# Altium schematic sheet Power_System.SchDoc
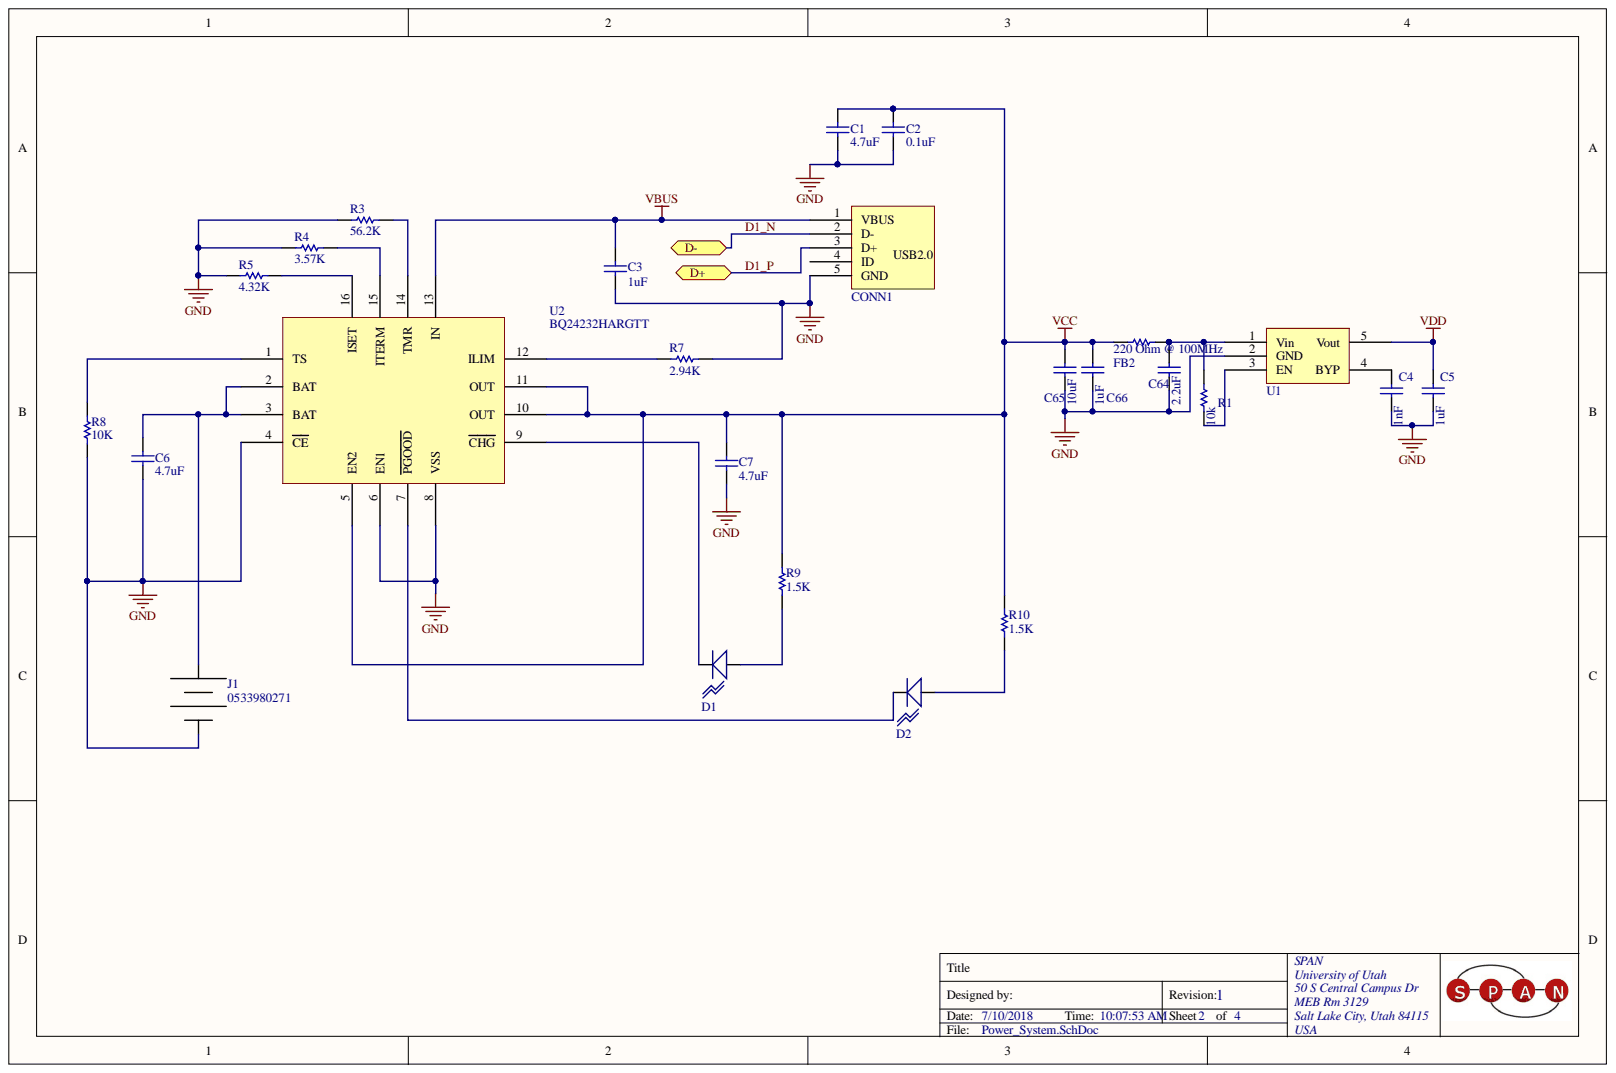
[diagram p0: Power_System.SchDoc]

## Parts
C1  4.7uF  [0603_Cap]
C2  0.1uF  [0603_Cap]
C3  1uF  [0603_Cap]
C4  10uF  [0603_Cap]  (value not drawn)
C5  GRM188R61A105KA61D  [CAPC1608X08N (0603)]  (value not drawn)
C6  4.7uF  [0603_Cap]
C7  4.7uF  [0603_Cap]
C64  GRM188F51A225ZE01D  [CAPC1608X08N (0603)]  (value not drawn)
C65  GRM188R60J106ME47D  [CAPC1608X08N (0603)]  (value not drawn)
C66  GRM188R61A105KA61D  [CAPC1608X08N (0603)]  (value not drawn)
CONN1  10118193-0001LF  [USB_micro_B]  (value not drawn)
D1  LTST-C191KFKT  [0603_LED]  (value not drawn)
D2  LTST-C191KFKT  [0603_LED]  (value not drawn)
FB2  Impedance @ Frequency: 220 Ohm @ 100MHz  [RESC1608X06N (0603)]  (hidden comment: BLM18BD221SN1D)
J1  0533980271  [53398-0271]
R1  10K  [0603_RES]
R3  56.2K  [0603_RES]
R4  3.57K  [0603_RES]
R5  4.32K  [0603_RES]
R7  2.94K  [0603_RES]
R8  10K  [0603_RES]
R9  1.5K  [0603_RES]
R10  1.5K  [0603_RES]
U1  TPS2147IDGQG4  [SOT95P280X145-5N]  (value not drawn)
U2  BQ24232HARGTT  [QFN_16_BQ24232]

## Ports
D+, D-

## Nets
GND: C1.1 C2.1 C3.1 C4.1 C5.1 C6.1 C7.1 C64.1 C65.1 C66.1 CONN1.5(GND) J1.2 R3.1 R4.1 R5.1 R7.2 R8.1 U1.2(GND) U2.4(CE) U2.6(EN1) U2.8(VSS)
VBUS: C3.2 CONN1.1(VBUS) U2.13(IN)
VCC: C1.2 C2.2 C7.2 C65.2 C66.2 FB2.1 R9.2 R10.2 U2.5(EN2) U2.10(OUT) U2.11(OUT)
VDD: C5.2 U1.5(Vout)
D1_N: CONN1.2(D-)
D1_P: CONN1.3(D+)
NetC4_2: C4.2 U1.4(BYP)
NetC6_2: C6.2 J1.1 U2.2(BAT) U2.3(BAT)
NetC64_2: C64.2 FB2.2 R1.2 U1.1(Vin)
NetD1_1: D1.1 R9.1
NetD1_2: D1.2 U2.9(CHG)
NetD2_1: D2.1 R10.1
NetD2_2: D2.2 U2.7(PGOOD)
NetR1_1: R1.1 U1.3(EN)
NetR3_2: R3.2 U2.14(TMR)
NetR4_2: R4.2 U2.15(ITERM)
NetR5_2: R5.2 U2.16(ISET)
NetR7_1: R7.1 U2.12(ILIM)
NetR8_2: R8.2 U2.1(TS)

Not connected: CONN1 4(ID)

## Library data of the parts
C1 C6 C7 = JMK107BJ475MAHT: Manufacturer: Taiyo Yuden; Capacitance: 4.7µF; Temperature Coefficient: X5R; Tolerance: ±20%; Voltage - Rated: 6.3V; Applications: Automotive, SMPS Filtering; Category: Capacitors; Component Kind: Standard; ComponentLink1Description: http://www.yuden.co.jp/productdata/catalog/en/mlcc_all_hq_e.pdf; ComponentLink1URL: http://www.yuden.co.jp/productdata/catalog/en/mlcc_all_hq_e.pdf; Description: 4.7uF; Failure Rate: -; Features: -; Height - Seated (Max): -; Lead Spacing: -; Lead Style: -; Manufacturer Part Number: JMK107BJ475MAHT; Mounting Type: Surface Mount, MLCC; Operating Temperature: -55°C ~ 85°C; Package / Case: 0603 (1608 Metric); Packaging: Tape & Reel (TR); Part Status: Active; Pricing 1: 4000=0.03053, 8000=0.0284, 12000=0.02698, 28000=0.02556, 100000=0.01935 (USD); Ratings: AEC-Q200; RoHS: RoHS Compliant; Size / Dimension: 0.063" L x 0.031" W (1.60mm x 0.80mm); Stock 1: 4000; Supplier 1: Digi-Key; Supplier Part Number 1: 587-4903-2-ND; Thickness (Max): 0.035" (0.90mm); Library Name: Sitara.SchLib
C2 = 0603YC104KAT4A: CAP CER 0.1UF 16V X7R 0603; Manufacturer: AVX Corporation; Capacitance: 0.1µF; Tolerance: ±10%; Applications: General Purpose; Category: Capacitors; Component Kind: Standard; ComponentLink1Description: http://datasheets.avx.com/X7RDielectric.pdf; ComponentLink1URL: http://datasheets.avx.com/X7RDielectric.pdf; Failure Rate: -; Features: -; Height - Seated (Max): -; Lead Spacing: -; Lead Style: -; Manufacturer Part Number: 0603YC104KAT4A; Mounting Type: Surface Mount, MLCC; Operating Temperature: -55°C ~ 125°C; Package / Case: 0603 (1608 Metric); Packaging: Tape & Reel (TR); Part Status: Active; Pricing 1: 15000=0.00344, 30000=0.00327, 75000=0.00292, 105000=0.00237 (USD); Ratings: -; RoHS: RoHS Compliant; Size / Dimension: 0.063" L x 0.032" W (1.60mm x 0.81mm); Stock 1: 15000; Supplier 1: Digi-Key; Supplier Part Number 1: 478-10054-2-ND; Temperature Coefficient: X7R; Thickness (Max): 0.035" (0.90mm); Voltage - Rated: 16V; Library Name: Sitara.SchLib
C3 = JMK107C6105KA-T: CAP CER 1uF SMD; Manufacturer: Taiyo Yuden; Capacitance: 1µF; Temperature Coefficient: X6S; Tolerance: ±10%; Voltage - Rated: 6.3V; Applications: SMPS Filtering; Category: Capacitors; Component Kind: Standard; ComponentLink1Description: http://www.yuden.co.jp/productdata/catalog/en/mlcc_all_e.pdf; ComponentLink1URL: http://www.yuden.co.jp/productdata/catalog/en/mlcc_all_e.pdf; Failure Rate: -; Features: -; Height - Seated (Max): -; Lead Spacing: -; Lead Style: -; Manufacturer Part Number: JMK107C6105KA-T; Mounting Type: Surface Mount, MLCC; Operating Temperature: -55°C ~ 105°C; Package / Case: 0603 (1608 Metric); Packaging: Tape & Reel (TR); Part Status: Active; Pricing 1: 4000=0.02281, 8000=0.02122, 12000=0.02016, 28000=0.0191, 100000=0.01446 (USD); Ratings: -; RoHS: RoHS Compliant; Size / Dimension: 0.063" L x 0.031" W (1.60mm x 0.80mm); Stock 1: 8000; Supplier 1: Digi-Key; Supplier Part Number 1: 587-4904-2-ND; Thickness (Max): 0.035" (0.90mm); Library Name: Sitara.SchLib
C4 = GRM1885C1H102JA01D: CAP CER 1000PF 50V 5% NP0 0603; Manufacturer: Murata Electronics North America; Temperature Coefficient: C0G, NP0; Applications: General Purpose; Capacitance: 1000pF; Category: Capacitors; Component Kind: Standard; ComponentLink1Description: http://www.murata.com/products/catalog/pdf/c02e.pdf; ComponentLink1URL: http://www.murata.com/products/catalog/pdf/c02e.pdf; Features: -; Height - Seated (Max): -; Lead Spacing: -; Lead Style: -; Manufacturer Part Number: GRM1885C1H102JA01D; Mounting Type: Surface Mount, MLCC; Operating Temperature: -55°C ~ 125°C; Package / Case: 0603 (1608 Metric); Packaging: Tape & Reel (TR); Pricing 1: 4000=0.00863, 8000=0.00788, 12000=0.0075, 28000=0.00713, 100000=0.00516 (USD); Ratings: -; RoHS: RoHS Compliant; Size / Dimension: 0.063" L x 0.031" W (1.60mm x 0.80mm); Stock 1: 140000; Supplier 1: Digi-Key; Thickness (Max): 0.035" (0.90mm); Tolerance: ±5%; Voltage - Rated: 50V; Library Name: Sara.SchLib
C5 C66 = GRM188R61A105KA61D: CAP CER 1UF 10V 10% X5R 0603; Manufacturer: Murata Electronics North America; Capacitance: 1.0µF; Applications: General Purpose; Category: Capacitors; Component Kind: Standard; ComponentLink1Description: http://search.murata.co.jp/Ceramy/image/img/A01X/G101/ENG/GRM188R61A105KA61-01.pdf; ComponentLink1URL: http://search.murata.co.jp/Ceramy/image/img/A01X/G101/ENG/GRM188R61A105KA61-01.pdf; Features: -; Height - Seated (Max): -; Lead Spacing: -; Lead Style: -; Manufacturer Part Number: GRM188R61A105KA61D; Mounting Type: Surface Mount, MLCC; Operating Temperature: -55°C ~ 85°C; Package / Case: 0603 (1608 Metric); Packaging: Cut Tape (CT); Pricing 1: 1=0.1, 10=0.041, 50=0.0224, 100=0.019, 250=0.01552, 500=0.01328, 1000=0.01035 (USD); Ratings: -; RoHS: RoHS Compliant; Size / Dimension: 0.063" L x 0.031" W (1.60mm x 0.80mm); Stock 1: 244919; Supplier 1: Digi-Key; Temperature Coefficient: X5R; Thickness (Max): 0.035" (0.90mm); Tolerance: ±10%; Voltage - Rated: 10V; Library Name: Sara.SchLib
C64 = GRM188F51A225ZE01D: CAP CER 2.2UF 10V Y5V 0603; Manufacturer: Murata Electronics North America; Capacitance: 2.2µF; Temperature Coefficient: Y5V (F); Tolerance: -20%, +80%; Applications: General Purpose; Category: Capacitors; Component Kind: Standard; ComponentLink1Description: http://search.murata.co.jp/Ceramy/image/img/A01X/G101/ENG/GRM188F51A225ZE01-01.pdf; ComponentLink1URL: http://search.murata.co.jp/Ceramy/image/img/A01X/G101/ENG/GRM188F51A225ZE01-01.pdf; Features: -; Height - Seated (Max): -; Lead Spacing: -; Lead Style: -; Manufacturer Part Number: GRM188F51A225ZE01D; Mounting Type: Surface Mount, MLCC; Operating Temperature: -30°C ~ 85°C; Package / Case: 0603 (1608 Metric); Packaging: Tape & Reel (TR); Pricing 1: 4000=0.02043, 8000=0.019, 12000=0.01805, 28000=0.0171 (USD); Ratings: -; RoHS: RoHS Compliant; Size / Dimension: 0.063" L x 0.031" W (1.60mm x 0.80mm); Stock 1: 8000; Supplier 1: Digi-Key; Thickness (Max): 0.035" (0.90mm); Voltage - Rated: 10V; Library Name: Sara.SchLib
C65 = GRM188R60J106ME47D: CAP CER 10UF 6.3V 20% X5R 0603; Manufacturer: Murata Electronics North America; Capacitance: 10µF; Applications: General Purpose; Category: Capacitors; Component Kind: Standard; ComponentLink1Description: http://search.murata.co.jp/Ceramy/image/img/A01X/G101/ENG/GRM188R60J106ME47-01.pdf; ComponentLink1URL: http://search.murata.co.jp/Ceramy/image/img/A01X/G101/ENG/GRM188R60J106ME47-01.pdf; Features: -; Height - Seated (Max): -; Lead Spacing: -; Lead Style: -; Manufacturer Part Number: GRM188R60J106ME47D; Mounting Type: Surface Mount, MLCC; Operating Temperature: -55°C ~ 85°C; Package / Case: 0603 (1608 Metric); Packaging: Digi-Reel®; Pricing 1: 1=0.24, 10=0.2, 50=0.1126, 100=0.1, 250=0.075, 500=0.06626, 1000=0.05875 (USD); Ratings: -; RoHS: RoHS Compliant; Size / Dimension: 0.063" L x 0.031" W (1.60mm x 0.80mm); Stock 1: 2128324; Supplier 1: Digi-Key; Supplier 2: Digi-Key; Temperature Coefficient: X5R; Thickness (Max): 0.035" (0.90mm); Tolerance: ±20%; Voltage - Rated: 6.3V; Library Name: Sara.SchLib
CONN1 = 10118193-0001LF: CONN USB MICRO B RECPT SMT R/A; Manufacturer: Amphenol FCI; Connector Type: USB - micro B; Current Rating: 1.8A per Contact; Gender: Receptacle; Material Flammability Rating: UL94 V-0; Mating Cycles: 10000; Number of Contacts: 5; Number of Ports: 1; Shielding: Shielded; Specifications: USB 2.0; Voltage - Rated: 100VAC; Category: Connectors, Interconnects; Component Kind: Standard; ComponentLink1Description: http://portal.fciconnect.com/Comergent//fci/drawing/10118193.pdf; ComponentLink1URL: http://portal.fciconnect.com/Comergent//fci/drawing/10118193.pdf; Contact Finish: Gold; Features: Solder Retention; Ingress Protection: -; Insulation Color: Black; Manufacturer Part Number: 10118193-0001LF; Mounting Feature: Horizontal; Mounting Type: Surface Mount, Right Angle; Through Hole; Operating Temperature: -30°C ~ 80°C; Packaging: Tape & Reel (TR); Part Status: Active; Pricing 1: 1200=0.19845, 2400=0.18144, 6000=0.1701, 8400=0.15876, 12000=0.15309, 30000=0.15082, 60000=0.14742 (USD); RoHS: RoHS Compliant; Shell/Shielding Material: Steel, Stainless; Stock 1: 88800; Supplier 1: Digi-Key; Supplier Part Number 1: 609-4616-2-ND; Termination: Solder; Library Name: Sitara.SchLib
D1 D2 = LTST-C191KFKT: LED ORANGE CLEAR 0603 SMD; Manufacturer: Lite-On Inc.; Current - Test: 20mA; Lens Color: Colorless; Lens Style/Size: Rectangle with Flat Top, 1.10mm x 0.80mm; Millicandela Rating: 90mcd; Viewing Angle: 130°; Voltage - Forward (Vf) (Typ): 2V; Wavelength - Dominant: 605nm; Wavelength - Peak: 611nm; Category: Optoelectronics; Color: Orange; Component Kind: Standard; ComponentLink1Description: http://optoelectronics.liteon.com/upload/download/DS22-2000-222/S_110_LTST-C191KFKT.pdf; ComponentLink1URL: http://optoelectronics.liteon.com/upload/download/DS22-2000-222/S_110_LTST-C191KFKT.pdf; Features: -; Height (Max): 0.55mm; Lens Transparency: Clear; Manufacturer Part Number: LTST-C191KFKT; Mounting Type: Surface Mount; Package / Case: 0603 (1608 Metric); Packaging: Tape & Reel (TR); Part Status: Active; Pricing 1: 5000=0.0376, 10000=0.03384, 25000=0.03008, 50000=0.02726, 125000=0.02538 (USD); RoHS: RoHS Compliant; Size / Dimension: 1.60mm L x 0.80mm W; Stock 1: 160000; Supplier 1: Digi-Key; Supplier Part Number 1: 160-1445-2-ND; Library Name: Sitara.SchLib
FB2 = BLM18BD221SN1D: FERRITE CHIP 220 OHM 200MA 0603; Manufacturer: Murata Electronics North America; DC Resistance (DCR): 450 mOhm Max; Filter Type: Differential Mode - Single; Category: Filters; Component Kind: Standard; ComponentLink1Description: http://search.murata.co.jp/Ceramy/image/img/PDF/ENG/L0110S0100BLM18B.pdf; ComponentLink1URL: http://search.murata.co.jp/Ceramy/image/img/PDF/ENG/L0110S0100BLM18B.pdf; Current Rating: 200mA; Height (Max): 0.037" (0.95mm); Manufacturer Part Number: BLM18BD221SN1D; Mounting Type: Surface Mount; Package / Case: 0603 (1608 Metric); Packaging: Cut Tape (CT); Pricing 1: 1=0.1, 10=0.087, 50=0.0712, 100=0.0523, 250=0.038, 500=0.03484, 1000=0.0301 (USD); RoHS: RoHS Compliant; Size / Dimension: 0.063" L x 0.031" W (1.60mm x 0.80mm); Stock 1: 35645; Supplier 1: Digi-Key; Library Name: Sara.SchLib
J1 = 0533980271: CONN HEADER 2POS 1.25MM VERT SMD; Manufacturer: Molex Inc; Connector Type: Header, Male Blades; Number of Positions: 2; Pitch: 0.049" (1.25mm); Category: Connectors, Interconnects; Color: Natural; Component Kind: Standard; ComponentLink1Description: http://www.molex.com/pdm_docs/sd/533980271_sd.pdf; ComponentLink1URL: http://www.molex.com/pdm_docs/sd/533980271_sd.pdf; Connector Style: -; Features: -; Manufacturer Part Number: 0533980271; Mounting Type: Surface Mount; Packaging: Cut Tape (CT); Pricing 1: 1=1.4, 10=1.091, 25=1.0168, 50=0.923, 100=0.8323, 250=0.7014, 500=0.66448 (USD); RoHS: RoHS Compliant; Stock 1: 14126; Supplier 1: Digi-Key; Supplier Part Number 1: WM7606CT-ND; Termination: Solder; Wire Gauge: -; Library Name: Chronos.SchLib
R1 = RC0603FR-0710KL: RES 10.0K OHM 1/10W 1% 0603 SMD; Manufacturer: Yageo; Power (Watts): 0.1W, 1/10W; Temperature Coefficient: ±100ppm/°C; Category: Resistors; Component Kind: Standard; ComponentLink1Description: http://www.yageo.com/documents/recent/PYu-RC0603_51_RoHS_L_4.pdf; ComponentLink1URL: http://www.yageo.com/documents/recent/PYu-RC0603_51_RoHS_L_4.pdf; Composition: Thick Film; Features: -; Height: 0.022" (0.55mm); Manufacturer Part Number: RC0603FR-0710KL; Number of Terminations: 2; Package / Case: 0603 (1608 Metric); Packaging: Tape & Reel (TR); Pricing 1: 5000=0.00171, 10000=0.00149, 25000=0.00131, 50000=0.0012, 125000=0.00117 (USD); Resistance (Ohms): 10k; RoHS: RoHS Compliant; Size / Dimension: 0.063" L x 0.031" W (1.60mm x 0.80mm); Stock 1: 11245000; Supplier 1: Digi-Key; Supplier Device Package: 0603; Tolerance: ±1%; Library Name: Sara.SchLib
R3 = ERA-3AEB5622V: RES SMD 56.2KOHM 0.1% 1/10W 0603; Manufacturer: Panasonic Electronic Components; Power (Watts): 0.1W, 1/10W; Temperature Coefficient: ±25ppm/°C; Category: Resistors; Component Kind: Standard; ComponentLink1Description: https://industrial.panasonic.com/cdbs/www-data/pdf/RDA0000/AOA0000C237.pdf; ComponentLink1URL: https://industrial.panasonic.com/cdbs/www-data/pdf/RDA0000/AOA0000C237.pdf; Composition: Thin Film; Failure Rate: -; Features: Automotive AEC-Q200; Height - Seated (Max): 0.022" (0.55mm); Manufacturer Part Number: ERA-3AEB5622V; Number of Terminations: 2; Operating Temperature: -55°C ~ 155°C; Package / Case: 0603 (1608 Metric); Packaging: Tape & Reel (TR); Part Status: Active; Pricing 1: 5000=0.0354, 10000=0.03304, 25000=0.03139, 50000=0.03075, 125000=0.03009 (USD); Resistance (Ohms): 56.2k; RoHS: RoHS Compliant; Size / Dimension: 0.063" L x 0.031" W (1.60mm x 0.80mm); Stock 1: 5000; Supplier 1: Digi-Key; Supplier Device Package: 0603; Supplier Part Number 1: P56.2KDBTR-ND; Tolerance: ±0.1%; Library Name: Sitara.SchLib
R4 = TNPW06033K57BEEA: RES SMD 3.57KOHM 0.1% 1/10W 0603; Manufacturer: Vishay Dale; Power (Watts): 0.1W, 1/10W; Temperature Coefficient: ±25ppm/°C; Category: Resistors; Component Kind: Standard; ComponentLink1Description: http://www.vishay.com/docs/28758/tnpw_e3.pdf; ComponentLink1URL: http://www.vishay.com/docs/28758/tnpw_e3.pdf; Composition: Thin Film; Failure Rate: -; Features: Anti-Sulfur, Automotive AEC-Q200, Moisture Resistant; Height - Seated (Max): 0.022" (0.55mm); Manufacturer Part Number: TNPW06033K57BEEA; Number of Terminations: 2; Operating Temperature: -55°C ~ 125°C; Package / Case: 0603 (1608 Metric); Packaging: Tape & Reel (TR); Part Status: Active; Pricing 1: 5000=0.1064 (USD); Resistance (Ohms): 3.57k; RoHS: RoHS Compliant; Size / Dimension: 0.063" L x 0.033" W (1.60mm x 0.85mm); Stock 1: 0; Supplier 1: Digi-Key; Supplier Device Package: 0603; Supplier Part Number 1: TNPW06033K57BEEA-ND; Tolerance: ±0.1%; Library Name: Sitara.SchLib
R5 = ERA-3AEB4321V: RES SMD 4.32KOHM 0.1% 1/10W 0603; Manufacturer: Panasonic Electronic Components; Power (Watts): 0.1W, 1/10W; Temperature Coefficient: ±25ppm/°C; Category: Resistors; Component Kind: Standard; ComponentLink1Description: https://industrial.panasonic.com/cdbs/www-data/pdf/RDA0000/AOA0000C237.pdf; ComponentLink1URL: https://industrial.panasonic.com/cdbs/www-data/pdf/RDA0000/AOA0000C237.pdf; Composition: Thin Film; Failure Rate: -; Features: Automotive AEC-Q200; Height - Seated (Max): 0.022" (0.55mm); Manufacturer Part Number: ERA-3AEB4321V; Number of Terminations: 2; Operating Temperature: -55°C ~ 155°C; Package / Case: 0603 (1608 Metric); Packaging: Tape & Reel (TR); Part Status: Active; Pricing 1: 5000=0.0354, 10000=0.03304, 25000=0.03139, 50000=0.03075, 125000=0.03009 (USD); Resistance (Ohms): 4.32k; RoHS: RoHS Compliant; Size / Dimension: 0.063" L x 0.031" W (1.60mm x 0.80mm); Stock 1: 5000; Supplier 1: Digi-Key; Supplier Device Package: 0603; Supplier Part Number 1: P4.32KDBTR-ND; Tolerance: ±0.1%; Library Name: Sitara.SchLib
R7 = ERA-3AEB2941V: RES SMD 2.94KOHM 0.1% 1/10W 0603; Manufacturer: Panasonic Electronic Components; Power (Watts): 0.1W, 1/10W; Temperature Coefficient: ±25ppm/°C; Category: Resistors; Component Kind: Standard; ComponentLink1Description: https://industrial.panasonic.com/cdbs/www-data/pdf/RDA0000/AOA0000C237.pdf; ComponentLink1URL: https://industrial.panasonic.com/cdbs/www-data/pdf/RDA0000/AOA0000C237.pdf; Composition: Thin Film; Failure Rate: -; Features: Automotive AEC-Q200; Height - Seated (Max): 0.022" (0.55mm); Manufacturer Part Number: ERA-3AEB2941V; Number of Terminations: 2; Operating Temperature: -55°C ~ 155°C; Package / Case: 0603 (1608 Metric); Packaging: Tape & Reel (TR); Part Status: Active; Pricing 1: 5000=0.0354, 10000=0.03304, 25000=0.03139, 50000=0.03075, 125000=0.03009 (USD); Resistance (Ohms): 2.94k; RoHS: RoHS Compliant; Size / Dimension: 0.063" L x 0.031" W (1.60mm x 0.80mm); Stock 1: 10000; Supplier 1: Digi-Key; Supplier Device Package: 0603; Supplier Part Number 1: P2.94KDBTR-ND; Tolerance: ±0.1%; Library Name: Sitara.SchLib
R8 = PHT0603Y1002BGT200: RES SMD 10KOHM 0.1% 0.0375W 0603; Manufacturer: Vishay Sfernice; Temperature Coefficient: ±15ppm/°C; Category: Resistors; Component Kind: Standard; ComponentLink1Description: http://www.vishay.com/docs/53050/pht.pdf; ComponentLink1URL: http://www.vishay.com/docs/53050/pht.pdf; Composition: Thin Film; Failure Rate: -; Features: Anti-Sulfur; Height - Seated (Max): 0.025" (0.64mm); Manufacturer Part Number: PHT0603Y1002BGT200; Number of Terminations: 2; Operating Temperature: -55°C ~ 215°C; Package / Case: 0603 (1608 Metric); Packaging: Tape & Reel (TR); Part Status: Active; Power (Watts): 0.0375W; Pricing 1: 200=6.6496, 400=6.0262, 600=5.6106, 1000=5.195 (USD); Resistance (Ohms): 10k; RoHS: RoHS Compliant; Size / Dimension: 0.060" L x 0.033" W (1.52mm x 0.85mm); Stock 1: 400; Supplier 1: Digi-Key; Supplier Device Package: 0603; Supplier Part Number 1: PHTB-10KTR-ND; Tolerance: ±0.1%; Library Name: Sitara.SchLib
R9 R10 = CRCW06031K50JNEA: RES SMD 1.5K OHM 5% 1/10W 0603; Manufacturer: Vishay Dale; Power (Watts): 0.1W, 1/10W; Temperature Coefficient: ±200ppm/°C; Category: Resistors; Component Kind: Standard; ComponentLink1Description: http://www.vishay.com/docs/20035/dcrcwe3.pdf; ComponentLink1URL: http://www.vishay.com/docs/20035/dcrcwe3.pdf; Composition: Thick Film; Failure Rate: -; Features: Automotive AEC-Q200; Height - Seated (Max): 0.020" (0.50mm); Manufacturer Part Number: CRCW06031K50JNEA; Number of Terminations: 2; Operating Temperature: -55°C ~ 155°C; Package / Case: 0603 (1608 Metric); Packaging: Tape & Reel (TR); Part Status: Active; Pricing 1: 5000=0.00266, 10000=0.00231, 25000=0.00203, 50000=0.0019 (USD); Resistance (Ohms): 1.5k; RoHS: RoHS Compliant; Size / Dimension: 0.063" L x 0.033" W (1.60mm x 0.85mm); Stock 1: 30000; Supplier 1: Digi-Key; Supplier Device Package: 0603; Supplier Part Number 1: 541-1.5KGTR-ND; Tolerance: ±5%; Library Name: Sitara.SchLib
U1 = FAN2500S30X: IC REG LDO 3V 0.1A SOT23-5; Manufacturer: Fairchild Semiconductor; Current - Output: 100mA; Number of Regulators: 1; Regulator Topology: Positive Fixed; Voltage - Dropout (Typical): 0.1V @ 100mA; Voltage - Input: Up to 6.5V; Category: Integrated Circuits (ICs); Component Kind: Standard; ComponentLink1Description: http://www.fairchildsemi.com/ds/FA/FAN2500.pdf; ComponentLink1URL: http://www.fairchildsemi.com/ds/FA/FAN2500.pdf; Current - Limit (Min): -; Manufacturer Part Number: FAN2500S30X; Mounting Type: Surface Mount; Operating Temperature: -40°C ~ 125°C; Package / Case: SC-74A, SOT-753; Packaging: Tape & Reel (TR); Pricing 1: 3000=0.25462, 6000=0.23706, 15000=0.22828, 30000=0.2195, 75000=0.21599, 150000=0.21072 (USD); RoHS: RoHS Compliant; Stock 1: 6000; Supplier 1: Digi-Key; Supplier Device Package: SOT-23-5; Voltage - Output: 3V; Library Name: Sara.SchLib
U2 = BQ24232HARGTT: IC LI-ION CHARGE PWR MGMT 16VQFN; Manufacturer: Texas Instruments; Battery Chemistry: Lithium-Ion; Battery Pack Voltage: 4.2V; Charge Current - Max: 500mA; Current - Charging: Constant - Programmable; Fault Protection: Over Temperature, Over Voltage, Reverse Current, Short Circuit; Interface: USB; Number of Cells: 1; Programmable Features: Current, Timer; Voltage - Supply (Max): 10.2V; Category: Integrated Circuits (ICs); Component Kind: Standard; ComponentLink1Description: http://www.ti.com/lit/ds/symlink/bq24232ha.pdf; ComponentLink1URL: http://www.ti.com/lit/ds/symlink/bq24232ha.pdf; Manufacturer Part Number: BQ24232HARGTT; Operating Temperature: -5°C ~ 125°C (TJ); Package / Case: 16-VFQFN Exposed Pad; Packaging: Tape & Reel (TR); Part Status: Active; Pricing 1: 250=2.09792, 500=1.88244, 1250=1.5876 (USD); RoHS: RoHS Compliant; Stock 1: 0; Supplier 1: Digi-Key; Supplier Device Package: 16-QFN (3x3); Supplier Part Number 1: 296-44194-2-ND; Library Name: Sitara.SchLib
